# Altium schematic sheet LPS22DF.SchDoc
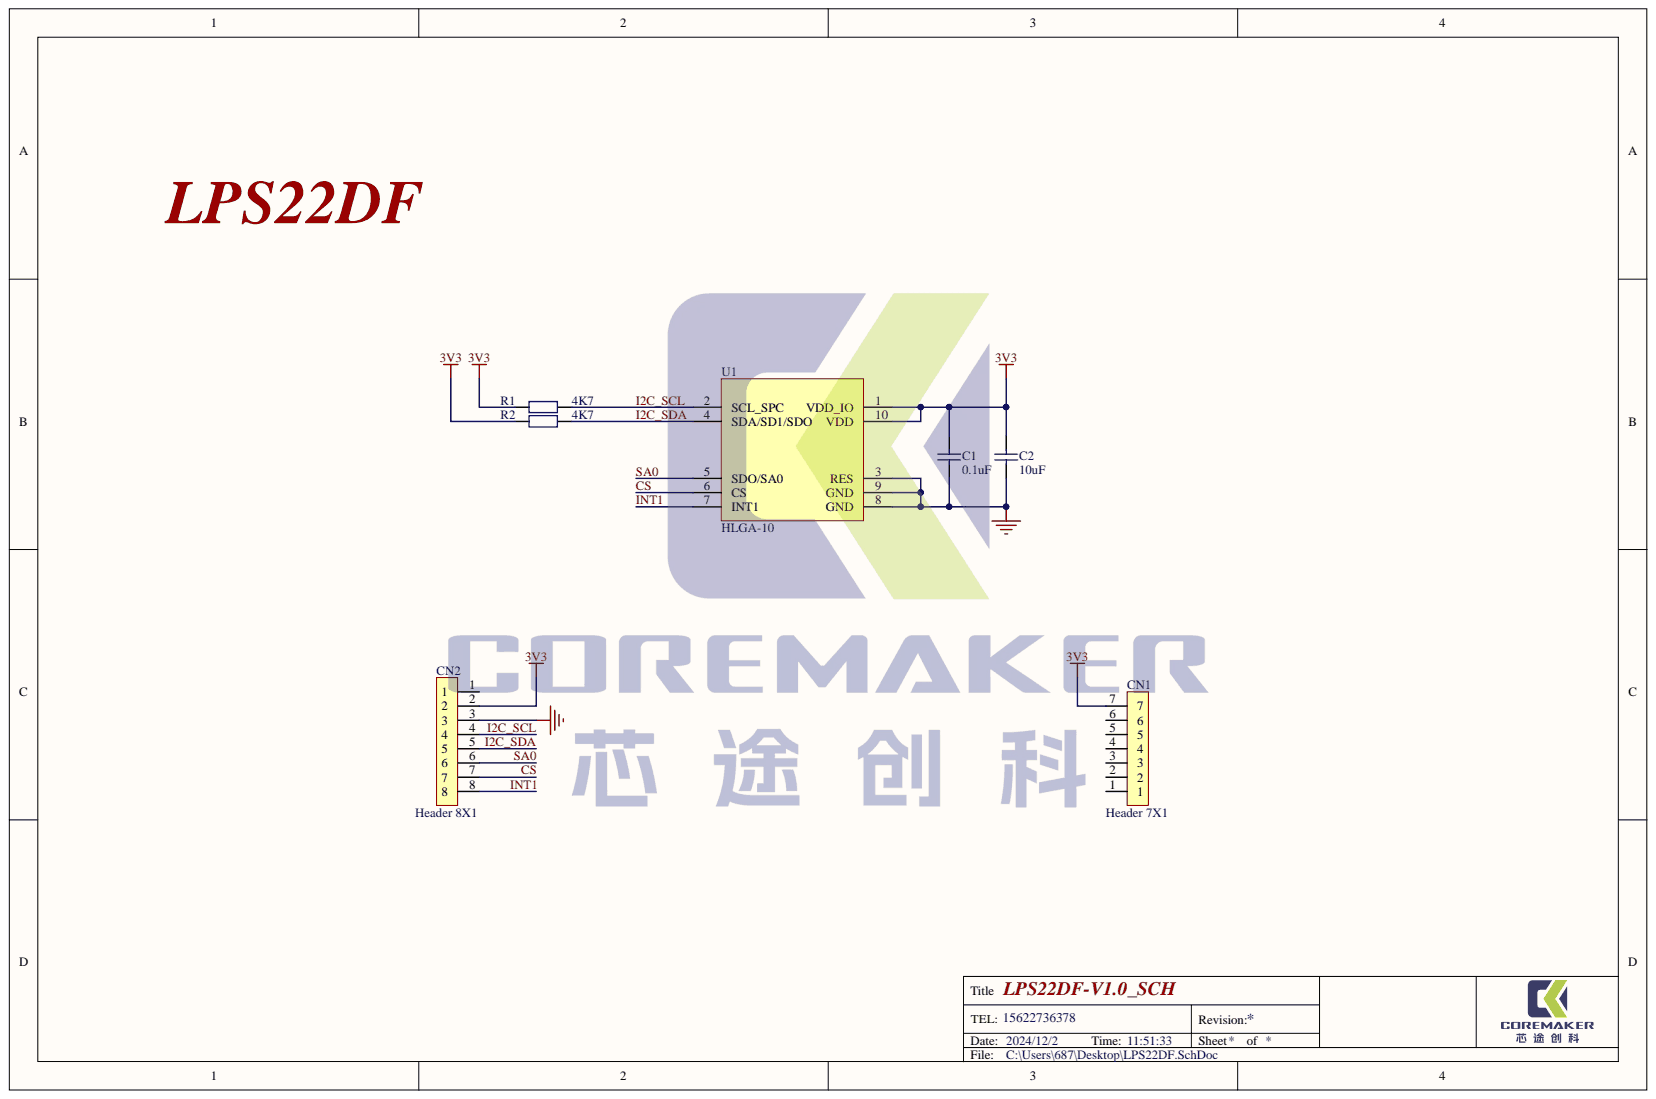
[diagram p0: LPS22DF.SchDoc]

## Parts
C1  0.1uF  [CAP_0603]
C2  10uF  [CAP_0603]
CN1  Header 7X1  [1X7PIN]
CN2  Header 8X1  [1X8PIN]
R1  4K7  [RES_0603]
R2  4K7  [RES_0603]
U1  HLGA-10  [HLGA-10L]

## Nets
3V3: C1.1 C2.1 CN1.7 CN2.2 R1.2 R2.2 U1.1 U1.10
GND: C1.2 C2.2 CN2.3 U1.3 U1.8 U1.9
CS: CN2.7 U1.6
I2C_SCL: CN2.4 R1.1 U1.2
I2C_SDA: CN2.5 R2.1 U1.4
INT1: CN2.8 U1.7
SA0: CN2.6 U1.5

Not connected: CN1 1 2 3 4 5 6; CN2 1

## Library data of the parts
C1 C2 = CAP: Component Kind: Standard; Description: CAP; Library Name: ST_2.4G.SchLib
R1 R2 = Res: Component Kind: Standard; Description: Res; Library Name: ST_2.4G.SchLib
CN1 = Header 7X1: Component Kind: Standard; Description: Header 7X1; Library Name: LSM6DS3TR-C_PCB.SchLib
CN2 = Header 8X1: Component Kind: Standard; Description: Header 8X1; Library Name: 1019_WB55_Senser.SchLib
U1 = HLGA-10: Component Kind: Standard; Description: HLGA-10; Library Name: LPS25HB_PCB.SchLib
